# Altium schematic sheet Power_Supply.SchDoc
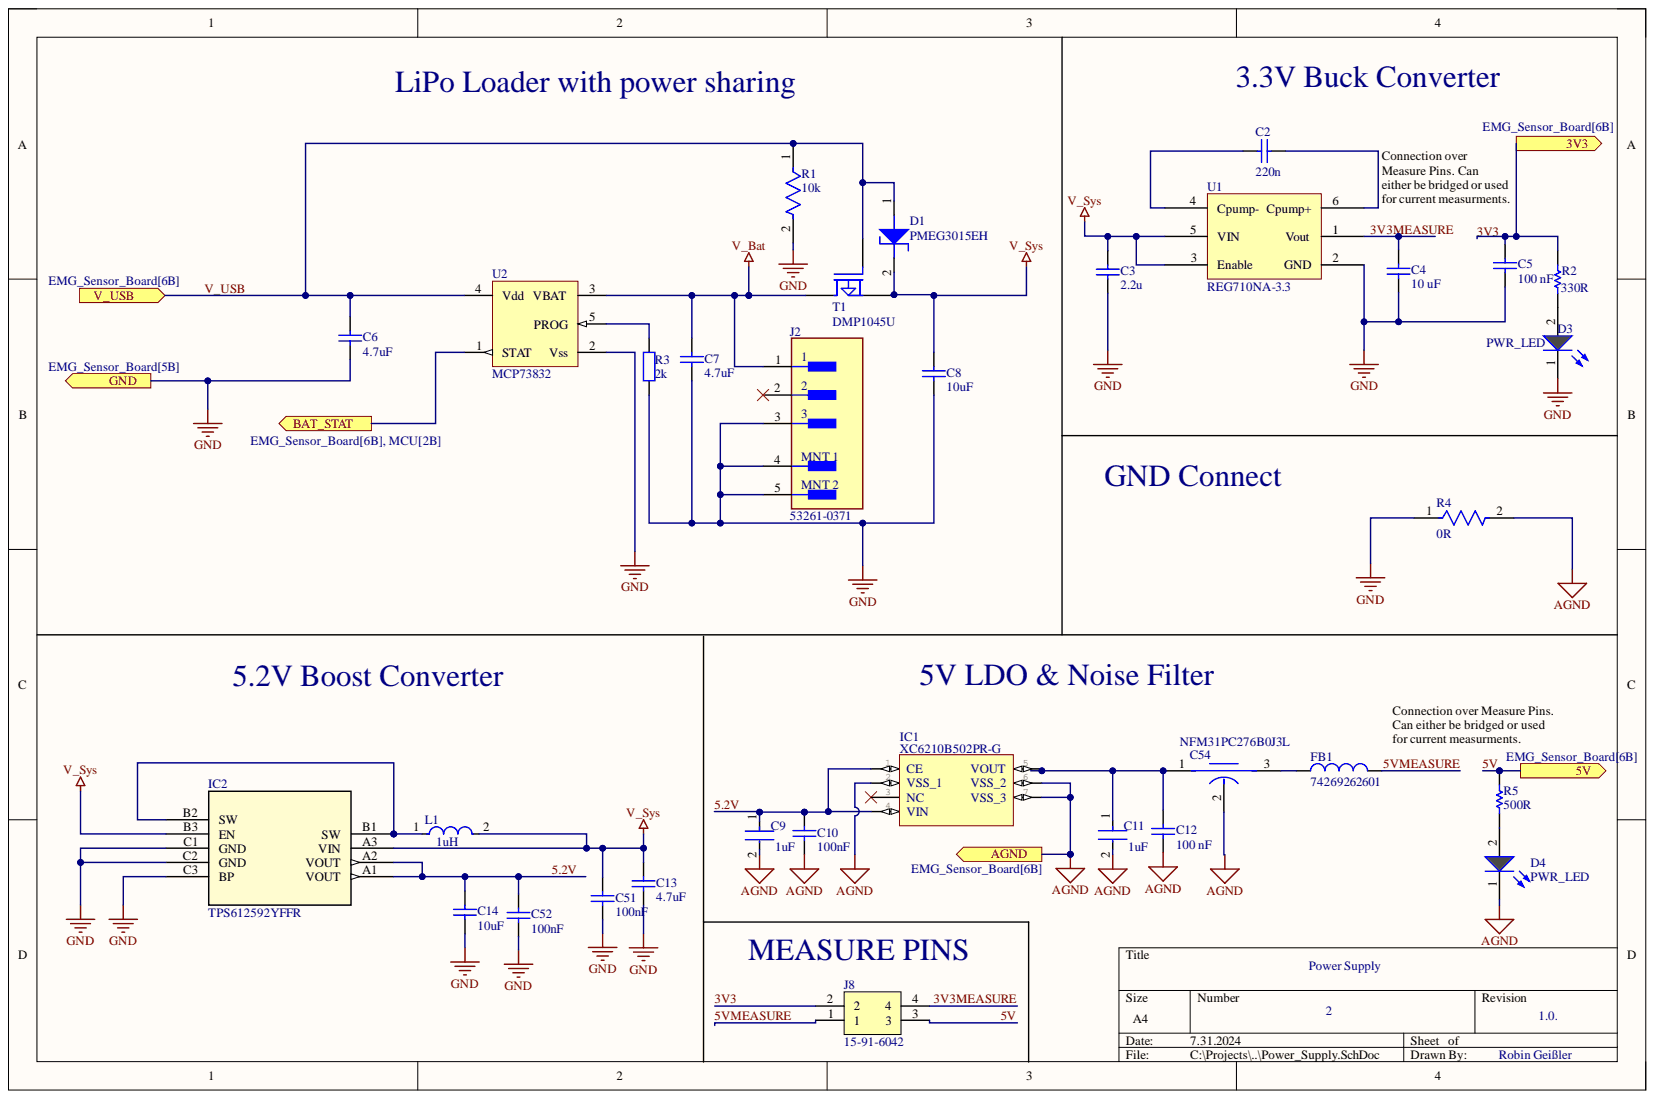
[diagram p0: Power_Supply.SchDoc]

## Parts
C2  220n  [C-1608 [0603]-N]
C3  2.2u  [C-1608 [0603]-N]
C4  10 uF  [C-1608 [0603]-N]
C5  100 nF  [C-1608 [0603]-N]
C6  4.7uF  [C-1608 [0603]-N]
C7  4.7uF  [C-1608 [0603]-N]
C8  10uF  [C-1608 [0603]-N]
C9  1uF  [FP-CGA3E-0_3-0_1-IPC_B]
C10  100nF  [C-1608 [0603]-N]
C11  1uF  [FP-CGA3E-0_3-0_1-MFG]
C12  100 nF  [C-1608 [0603]-N]
C13  4.7uF  [C-1608 [0603]-N]
C14  10uF  [C-1608 [0603]-N]
C51  100nF  [C-1608 [0603]-N]
C52  100nF  [C-1608 [0603]-N]
C54  NFM31PC276B0J3L  [FP-NFM31-0_2-MFG]
D1  PMEG3015EH  [SOD-123-D]
D3  PWR_LED  [WL-SMCW_0603_150060xx75000]
D4  PWR_LED  [WL-SMCW_0603_150060xx75000]
FB1  74269262601  [WE-TMSB_0603_HC]
IC1  XC6210B502PR-G  [XC6210B502PRG]
IC2  TPS612592YFFR  [DSBGA09_1P206X1P306_TEX]
J2  53261-0371  [FP-53261-0371-MFG]
J8  15-91-6042  [15916042]
L1  1uH  [FP-IHLP-1616BZ-FP_1_8545x-MFG]
R1  10k  [FP-RC0603-0_55-IPC_C]
R2  330R  [RESC1608X55X30LL15T15]
R3  2k  [C-1608 [0603]-N]
R4  0R  [FP-CRCW0603-e3-IPC_B]
R5  500R  [RESC1608X55X30NL15T15]
T1  DMP1045U  [SOT-23-3-PMOS]
U1  REG710NA-3.3  [SOT-23/6]
U2  MCP73832  [SOT95P280X145-5N]

## Ports
3V3, 5V, AGND, BAT_STAT, GND, V_USB

## Nets
V_Bat: C7.2 J2.1 T1.2 U2.3(VBAT)
V_Sys: C3.2 C8.2 C13.2 C51.1 D1.2 IC2.A3(VIN) IC2.B3(EN) L1.2 T1.3 U1.3(Enable) U1.5(VIN)
3V3: C5.1 J8.2 R2.2 ; port 3V3
3V3MEASURE: C4.2 J8.4 U1.1(Vout)
5.2V: C9.1 C10.1 C14.2 C52.1 IC1.1(CE) IC1.4(VIN) IC2.A1(VOUT) IC2.A2(VOUT)
5V: J8.3 R5.2 ; port 5V
5VMEASURE: FB1.2 J8.1
AGND: C9.2 C10.2 C11.2 C12.2 C54.2 D4.1 IC1.2(VSS_1) IC1.6(VSS_2) IC1.7(VSS_3) R4.2 ; port AGND
BAT_STAT: U2.1(STAT) ; port BAT_STAT
GND: C3.1 C4.1 C5.2 C6.1 C7.1 C8.1 C13.1 C14.1 C51.2 C52.2 D3.1 IC2.C1(GND) IC2.C2(GND) IC2.C3(BP) J2.3 J2.4 J2.5 R1.2 R3.1 R4.1 U1.2(GND) U2.2(Vss) ; port GND
V_USB: C6.2 D1.1 R1.1 T1.1 U2.4(Vdd) ; port V_USB
NetC2_1: C2.1 U1.4(Cpump-)
NetC2_2: C2.2 U1.6(Cpump+)
NetC11_1: C11.1 C12.1 C54.1 IC1.5(VOUT)
NetC54_3: C54.3 FB1.1
NetD3_2: D3.2 R2.1
NetD4_2: D4.2 R5.1
NetIC2_B1: IC2.B1(SW) IC2.B2(SW) L1.1
NetR3_2: R3.2 U2.5(PROG)

Not connected: IC1 3(NC); J2 2

## Library data of the parts
C2 = C220n_0603_CGA3E3X7R1H224K080AB: CAP 0603 50V 0.22uF X7R 10% AEC-Q200; Component Kind: Standard; Supplier 1: Mouser; Supplier Part Number 1: 810-CGA3E3X7R1H224K; Library Name: M3Infekt_V2.SchLib
C3 = C2u2_0603_GRM188R61E225KA12D: CAP 0603 2.2uF 25volts X5R 10%; Component Kind: Standard; Supplier 1: Mouser; Supplier Part Number 1: 81-GRM188R61E225KA2D; Library Name: M3Infekt_V2.SchLib
C4 C8 C14 = C10u_0603_GRM188R61E106KA73J: CAP CER 10UF 25V X5R 0603 10%; Component Kind: Standard; Supplier 1: Mouser; Supplier Part Number 1: 81-GRM188R61E106KA3J; Library Name: M3Infekt_V2.SchLib
C5 C12 = C100n_0603_C0603C104J4RAC: Multilayer Ceramic Capacitors MLCC - SMD/SMT 16volts 0.1uF 5% X7R; Manufacturer: KEMET; Capacitance: 100nF; Dissipation Factor: 3.5%; Voltage Rating: 16V; Case Code (Imperial): 0603; Case Code (Metric): 1608; Case/Package: 0603; Component Kind: Standard; Description 1: Multilayer Ceramic Capacitors MLCC - SMD/SMT 16volts 0.1uF 5% X7R; Dielectric: X7R; Dielectric Material: Ceramic; Height: 0.8mm; Length: 1.6mm; Manufacturer Part Number: C0603C104J4RAC; Max Operating Temperature: 125°C; Min Operating Temperature: -55°C; Package Quantity: 4000; Packaging: Tape and Reel; Pricing 1: 1=0.14, 10=0.055, 50=0.055, 100=0.038, 1000=0.026, 10000=0.018 (USD); Stock 1: 0; Supplier 1: Mouser; Supplier Part Number 1: 80-C0603C104J4RAC; Termination: SMD/SMT; Tolerance: 5%; Voltage Rating (DC): 16V; Width: 0.8mm; Library Name: SERENE_Shield.SchLib
C6 C7 C13 = C4u7_0603_C1608X5R1C475K080AE: CAP CER 0603 16V 4.70uF X5R 10%; Component Kind: Standard; Supplier 1: Mouser; Supplier Part Number 1: 810-C1608X5R1C475KE; Library Name: M3Infekt_V2.SchLib
C9 C11 = CMP-08246-002551-1: Cap Ceramic 1uF 35V X7R 10% Pad SMD 0603 +125°C Automotive T/R; Capacitance: 1uF; Case Code (Imperial): 0603; Case Code (Metric): 1608; Case/Package: 0603; Component Kind: Standard; Dielectric: X7R; Height: 0.8mm; Length: 0.063inch; Library Name: Altium Content Vault; Max Operating Temperature: 125°C; Min Operating Temperature: -55°C; Mount: Surface Mount; Package Quantity: 4000; Packaging: Tape and Reel; Ratings: AEC-Q200; Termination: SMD/SMT; Thickness: 0.035inch; Tolerance: 10%; Voltage Rating: 35V; Voltage Rating (DC): 35V; Weight: 7.1E-05oz; Width: 0.031inch
C10 C51 C52 = C100n_0603_C0603C104J4RAC: Multilayer Ceramic Capacitors MLCC - SMD/SMT 16volts 0.1uF 5% X7R; Manufacturer: KEMET; Dissipation Factor: 3.5%; Voltage Rating: 16V; Capacitance: 100nF; Case Code (Imperial): 0603; Case Code (Metric): 1608; Case/Package: 0603; Component Kind: Standard; Description 1: Multilayer Ceramic Capacitors MLCC - SMD/SMT 16volts 0.1uF 5% X7R; Dielectric: X7R; Dielectric Material: Ceramic; Height: 0.8mm; Length: 1.6mm; Manufacturer Part Number: C0603C104J4RAC; Max Operating Temperature: 125°C; Min Operating Temperature: -55°C; Package Quantity: 4000; Packaging: Tape and Reel; Pricing 1: 1=0.14, 10=0.055, 50=0.055, 100=0.038, 1000=0.026, 10000=0.018 (USD); Stock 1: 0; Supplier 1: Mouser; Supplier Part Number 1: 80-C0603C104J4RAC; Termination: SMD/SMT; Tolerance: 5%; Voltage Rating (DC): 16V; Width: 0.8mm; Library Name: SERENE_Shield.SchLib
C54 = CMP-2000-06846-2: Large Current 3 Terminals Low ESL Chip Multilayer Ceramic Capacitors for General Purpose, 1206, 27uF, 20%, 6.3V; Current: 6A; DC Resistance (DCR): 5mR; Insulation Resistance: 20MR; Capacitance: 27uF; Case Code (Imperial): 1206; Case Code (Metric): 3216; Case/Package: 1206; Component Kind: Standard; Current Rating: 6A; Depth: 1.6mm; Height: 1.3mm; Lead Free: Lead Free; Length: 3.2mm; Library Name: Altium Content Vault; Max Current Rating: 6A; Max Operating Temperature: 85°C; Max Voltage Rating (DC): 6.3V; Min Operating Temperature: -40°C; Mount: Surface Mount; Package Quantity: 1; Packaging: Tape and Reel; Radiation Hardening: No; REACH SVHC: No SVHC; Termination: Flat; Tolerance: 20%; Voltage: 6.3V; Voltage Rating (DC): 6.3V; Width: 1.6mm
D3 D4 = CMP-1426-00002-3: NexarPartId: 29687084; Color: Green; Dominant Wavelength: 525nm; Forward Current: 100mA; Forward Voltage: 3.2V; Lens Style: Clear, Rectangular; Luminous Intensity: 430mcd; Max Junction Temperature (Tj): 95°C; Max Reverse Voltage (DC): 5V; Output Current: 10µA; Peak Wavelength: 515nm; Power Dissipation: 105mW; Test Current: 20mA; Viewing Angle: 140°; Wavelength: 520nm; Case Code (Imperial): 0603; Case Code (Metric): 1608; Case/Package: 0603; Component Kind: Standard; Height: 700µm; Lead Free: Lead Free; Length: 1.6mm; Library Name: Altium Content Vault; Max Operating Temperature: 85°C; Min Operating Temperature: -40°C; Mount: Surface Mount; Packaging: Tape and Reel; REACH SVHC: Unknown; Reverse Voltage: 5V; RoHS: Compliant; Width: 800µm
FB1 = CMP-03913-130924275-1: 74269262601 Ferrite Bead, Suppression, 600 Ohm, 1.5A Rohs Compliant: Yes; DC Resistance (DCR): 90mΩ; Component Kind: Standard; Current Rating: 1.5A; Height: 800µm; Length: 1.6mm; Library Name: Altium Content Vault; Max Operating Temperature: 125°C; Min Operating Temperature: -55°C; NexarPartId: 130924275; RoHS: Compliant; Width: 800µm
IC1 = XC6210B502PR-G: LDO Voltage Regulators 700mA High Speed LDO Regulator   Source:  Datasheet; Manufacturer_Name: Torex; GateName_1: G$1; Component Kind: Standard; DeviceSetName: XC6210B502PR-G; Height: 1.6mm; LibraryName: XC6210B502PR-G; Manufacturer_Part_Number: XC6210B502PR-G; Mouser_Part_Number: 865-XC6210B502PR-G; Mouser_Price-Stock: https://www.mouser.co.uk/ProductDetail/Torex-Semiconductor/XC6210B502PR-G?qs=AsjdqWjXhJ8XXo5wZ7cEHQ%3D%3D; Package: XC6210B502PRG; SymbolName_1: XC6210B502PR-G; Library Name: EMG_Sensor.SchLib
IC2 = TPS612592YFFR: No Description Available; Mfr_Name: Texas Instruments; Component Kind: Standard; Manufacturer_Part_Number: TPS612592YFFR; RefDes: RefDes; Type: DEV; Copyright: Copyright (C) 2024 Ultra Librarian. All rights reserved.; Library Name: EMG_Sensor.SchLib
J2 = CMP-04776-000071-1: CONN HEADER SMD R/A 3POS 1.25MM; Manufacturer: Molex; Conn Gender: Male; Conn Orientation: Right Angle; Current Rating: 1A; Number Of Contacts: 3; Voltage Rating: 125V; Ambient Temperature Range High: +105°C; Ambient Temperature Range Low: -40°C; Automotive: No; Category: Conn; Component Kind: Standard; ComponentLink2Description: Datasheet; ComponentLink2URL: https://www.molex.com/webdocs/datasheets/pdf/en-us/0532610371_PCB_HEADERS.pdf; Contact Material: Tin,Nickel; Device Class L1: Connectors; Device Class L2: Headers and Wire Housings; Device Class L3: unset; Digikey Description: CONN HEADER SMD R/A 3POS 1.25MM; Footprint Url: https://www.molex.com/pdm_docs/sd/532610371_sd.pdf; Height: 3.6mm; Lead Free: Yes; Library Name: Altium Content Vault; Manufacturer Part Number: 53261-0371; Mouser Description: 1.25mm (.049") Pitch PicoBlade Header, Surface Mount, Right Angle, Lead-free, 3 Circuits; Number Of Rows: 1; Octopart: https://octopart.com/53261-0371-molex-655314; Package: 0; Pitch: 1.25mm; RoHS: TRUE; Temperature: -40°C to +105°C; Temperature Range High: +105°C; Temperature Range Low: -40°C
J8 = 15-91-6042: 2.54mm Pitch SL Wire-to-Board Header, Low Profile, Surface Mount, Single Row, Right-Angle, 3.05mm Pocket, Shrouded, with Press-fit Plastic Peg,  Circuits, Tin (Sn) Plating, Embossed Tape Packaging; Manufacturer_Name: Molex; Geometry.Height: 11.69mm; Component Kind: Standard; Datasheet Link: https://componentsearchengine.com/Datasheets/1/15-91-6042.pdf; Manufacturer_Part_Number: 15-91-6042; Mouser Part Number: 538-15-91-6042; Mouser Price/Stock: https://www.mouser.co.uk/ProductDetail/Molex/15-91-6042?qs=3DzawVMofg8HeBuyct9LCA%3D%3D; Library Name: EMG_Sensor.SchLib
L1 = CMP-02424-000048-1: Fixed Inductors Automotive High Saturation Series SMT 1uH 3.75A 37mΩ 20%; Connector Type: Power; DC Resistance (DCR): 37mR; Self Resonant Frequency: 90MHz; Shielding: Shielded; Test Frequency: 100kHz; Case/Package: 1616; Component Kind: Standard; Depth: 4.31mm; Height: 2mm; Inductance: 1uH; Lead Free: Lead Free; Length: 4.45mm; Library Name: Altium Content Vault; Max DC Current: 3.75A; Max Operating Temperature: 125°C; Military Standard: Not; Min Operating Temperature: -55°C; Package Quantity: 4000; Packaging: Tape and Reel; Radiation Hardening: No; Termination: SMD/SMT; Tolerance: 20%; Width: 4.06mm
R1 = CMP-2100-03664-2: RES 10K OHM 1% 1/10W 0603; Max Power Dissipation: 100mW; Resistance: 10kR; Temperature Coefficient: 100ppm/°C; Voltage Rating: 75V; Voltage Rating (DC): 50V; Case Code (Imperial): 0603; Case Code (Metric): 1608; Case/Package: 0603; Component Kind: Standard; Construction: Rectangular; Depth: 0.8mm; Features: Moisture Resistant; Height: 0.45mm; Lead Free: Lead Free; Lead Pitch: 0.3mm; Length: 1.6mm; Library Name: Altium Content Vault; Max Operating Temperature: 155°C; Military Standard: Not; Min Operating Temperature: -55°C; Number of Pins: 2; Number of Terminations: 2; Packaging: Tape and Reel; Power Rating: 100mW; Resistance Tolerance: 1%; Resistor Type: Thick Film; RoHS Compliant: Yes; Series: RC; Size Code: 0603; Termination: SMD/SMT; Tolerance: 1%; Weight: 7.1E-05oz; Width: 0.8mm
R2 = CMP-2000-00435-2: Chip Resistor, 330 Ohm, +/- 1%, 0.1 W, -55 to 155 degC, 0603 (1608 Metric), RoHS, Tape and Reel; Current Rating: 500 mA; Gender: Male; Mating Cycles: 10000; Voltage Rating: 10 V; Component Kind: Standard; ComponentLink1Description: Manufacturer URL; ComponentLink1URL: http://www.panasonic.com/; ComponentLink2Description: Datasheet; ComponentLink2URL: http://industrial.panasonic.com/cdbs/www-data/pdf/RDA0000/AOA0000C86.pdf; Contact Finish: Gold; Contact Material: Copper; Contact Plating: Gold; DatasheetVersion: Apr-15; Height: 1.45 mm; Lead Pitch: 1.1 mm; Length: 13.7 mm; Library Name: Altium Content Vault; Max Operating Temperature: 85 degC; Min Operating Temperature: -25 degC; Mount: Surface Mount; Package Quantity: 1500; PackageDescription: 2-Pin Surface Mount Device, Body 1.6 x 0.85 mm; PackageReference: 0603; Packaging: Tape and Reel; Pins: 8; REACH SVHC: No SVHC; RoHSCompliant: true; Width: 1.45 mm
R3 = R2k_0603_ERA-3AEB202V: PANASONIC ELECTRONIC COMPONENTS         ERA3AEB202V             SMD Chip Resistor, Thin Film, 2 kohm, 75 V, 0603 [1608 Metric], 100 mW,  0.1%, ERA Series; Max Power Dissipation: 100mW; Resistance: 2kR; Temperature Coefficient: 25ppm/°C; Voltage Rating: 75V; Case Code (Imperial): 0603; Case Code (Metric): 1608; Case/Package: 0603; Component Kind: Standard; ComponentLink1Description: http://www.farnell.com/datasheets/2052875.pdf; ComponentLink1URL: http://www.farnell.com/datasheets/2052875.pdf; ComponentLink2Description: http://www.farnell.com/datasheets/1776116.pdf; ComponentLink2URL: http://www.farnell.com/datasheets/1776116.pdf; ComponentLink3Description: http://www.farnell.com/datasheets/2059650.pdf; ComponentLink3URL: http://www.farnell.com/datasheets/2059650.pdf; ComponentLink4Description: http://www.alliedelec.com/m/d/b6b0537e86f421aa7f5975bb1d8f429a.pdf; ComponentLink4URL: http://www.alliedelec.com/m/d/b6b0537e86f421aa7f5975bb1d8f429a.pdf; ComponentLink5Description: http://www.farnell.com/datasheets/485442.pdf; ComponentLink5URL: http://www.farnell.com/datasheets/485442.pdf; ComponentLink6Description: https://www.distrelec.biz/Web/Downloads/_t/ds/ERA_eng_tds.pdf?mime=application%2Fpdf; ComponentLink6URL: https://www.distrelec.biz/Web/Downloads/_t/ds/ERA_eng_tds.pdf?mime=application%2Fpdf; ComponentLink7Description: http://industrial.panasonic.com/www-data/pdf/AOA0000/AOA0000CE26.pdf; ComponentLink7URL: http://industrial.panasonic.com/www-data/pdf/AOA0000/AOA0000CE26.pdf; ComponentLink8Description: http://www.produktinfo.conrad.com/datenblaetter/450000-474999/450879-da-01-en-WIDERSTAND_0603_ERA.pdf; ComponentLink8URL: http://www.produktinfo.conrad.com/datenblaetter/450000-474999/450879-da-01-en-WIDERSTAND_0603_ERA.pdf; Composition: Thin Film; Contact Plating: Tin; Depth: 0.8mm; Description 1: PANASONIC ELECTRONIC COMPONENTS         ERA3AEB202V             SMD Chip Resistor, Thin Film, 2 kohm, 75 V, 0603 [1608 Metric], 100 mW,  0.1%, ERA Series; Height: 0.45mm; Lead Free: Lead Free; Length: 1.6mm; Manufacturer: Panasonic; Manufacturer Part Number: ERA-3AEB202V; Max Operating Temperature: 155°C; Military Standard: Not; Min Operating Temperature: -55°C; Mount: Surface Mount; Number of Pins: 2; Number of Terminations: 2; Package Quantity: 5000; Packaging: Tape and Reel; Power Rating: 100mW; Radiation Hardening: No; REACH SVHC: No SVHC; Stock 1: 0; Supplier 1: Farnell; Supplier Part Number 1: 1811500RL; Termination: SMD/SMT; Tolerance: 0.1%; Voltage Rating (DC): 75V; Width: 0.8mm; Working Voltage: 75V; Library Name: SERENE_Shield.SchLib
R4 = CMP-2000-03818-2: RES Thick Film, 0Ω, 0.1W, 0603; Max Power Dissipation: 100mW; Resistance: 0mR; Temperature Coefficient: 100ppm/°C; Tolerance: 1%; Voltage Rating: 75V; Case Code (Imperial): 0603; Case Code (Metric): 1608; Case/Package: 0603; Component Kind: Standard; Composition: Thick Film; Contact Plating: Tin; Depth: 0.85mm; Features: Automotive AEC-Q200; Height: 0.45mm; Lead Free: Lead Free; Length: 1.55mm; Library Name: Altium Content Vault; Max Operating Temperature: 155°C; Military Standard: Not; Min Operating Temperature: -55°C; Number of Pins: 2; Number of Terminations: 2; Package Quantity: 10000; Packaging: Tape and Reel; Power Rating: 100mW; Radiation Hardening: No; Termination: SMD/SMT; Voltage Rating (DC): 75V; Weight: 7.1E-05oz; Width: 0.033inch
R5 = CMP-2000-00539-1: Manufacturer: Panasonic; Part Number: ERJ3EKF5100V; Component Kind: Standard; Library Name: Altium Content Vault
T1 = MOSFET P: Mouser-Nr.:621-DMP1045U-7; Component Kind: Standard; Supplier 1: Mouser; Supplier Part Number 1: 621-DMP1045U-7; Library Name: EMG_Sensor.SchLib
U1 = U_SOT23-6_REG710NA-3.3: Schaltspannungsregler 30-mA Switched-Cap DC-DC Converter, Buck-Boost, Vout = 3.3V; Component Kind: Standard; Supplier 1: Mouser; Supplier Part Number 1: 595-REG710NA-3.3/3K; Library Name: EMG_Sensor.SchLib
D1 = PMEG3015EH: Component Kind: Standard; Library Name: EMG_Sensor.SchLib
U2 = MCP73832: Component Kind: Standard; Supplier 1: Mouser; Supplier Part Number 1: 579-MCP73832T-2ACIOT; Library Name: EMG_Sensor.SchLib
